# Altium schematic sheet led_clock-LEDR.SchDoc
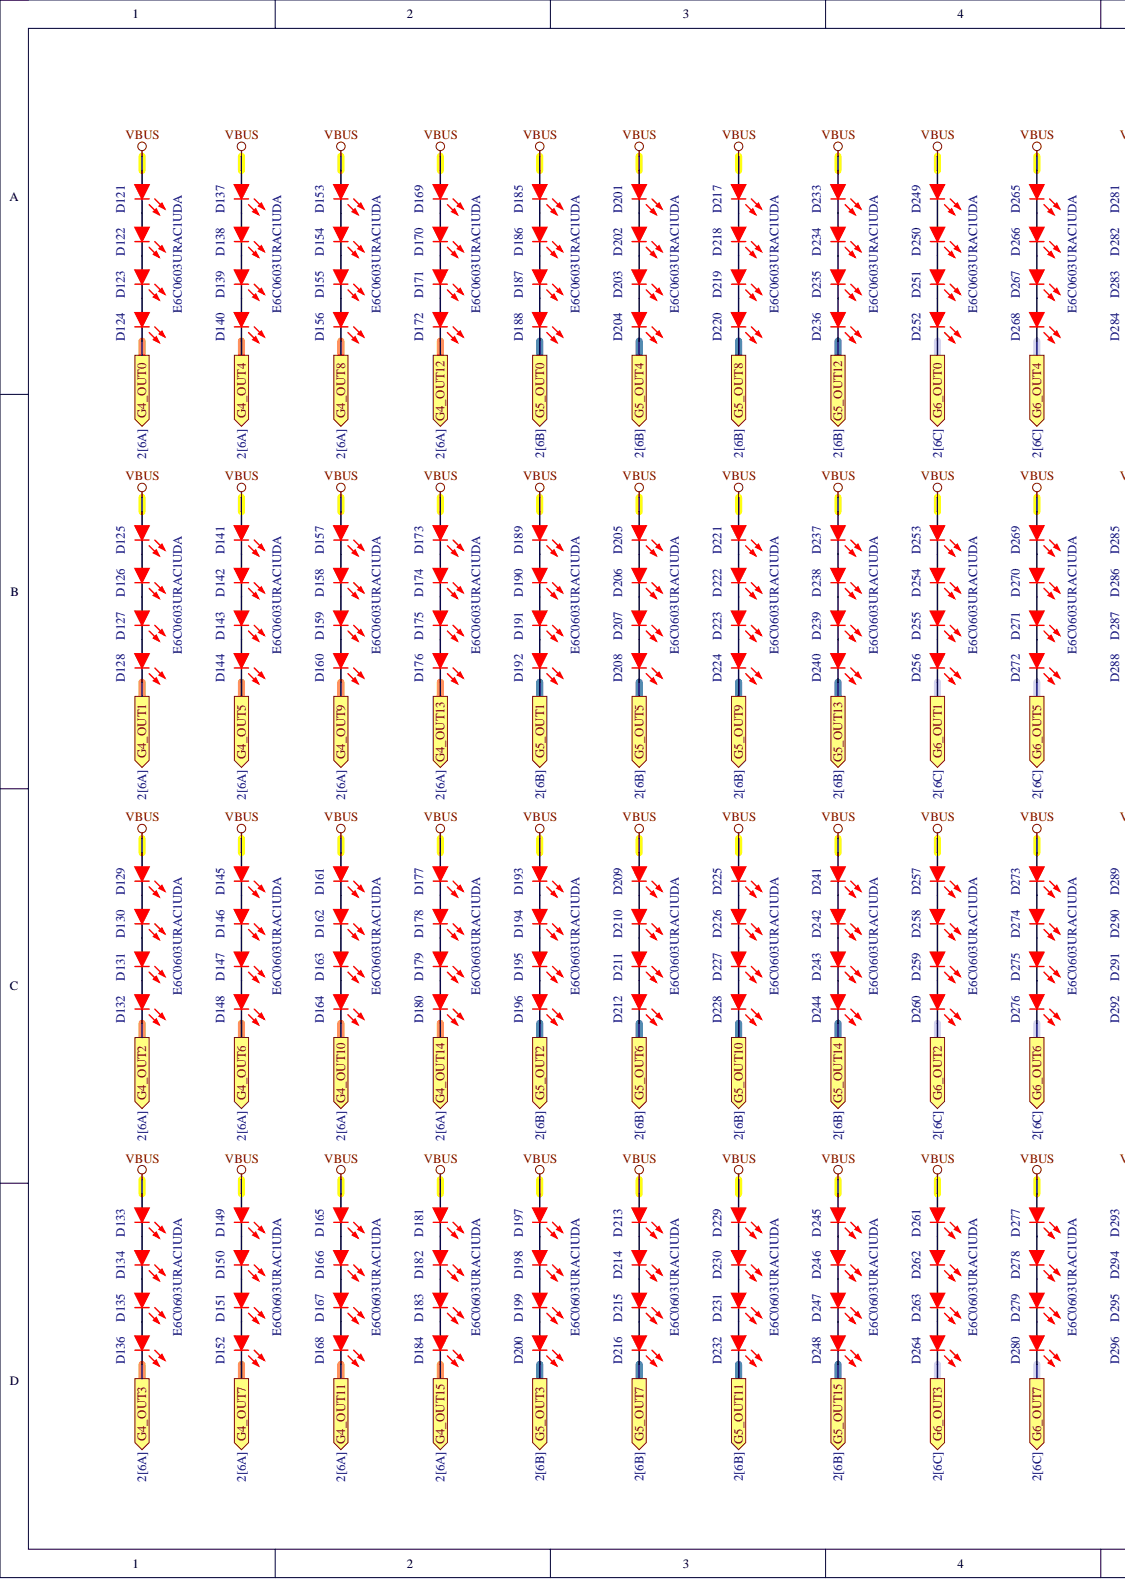
[diagram p0: led_clock-LEDR.SchDoc, left]
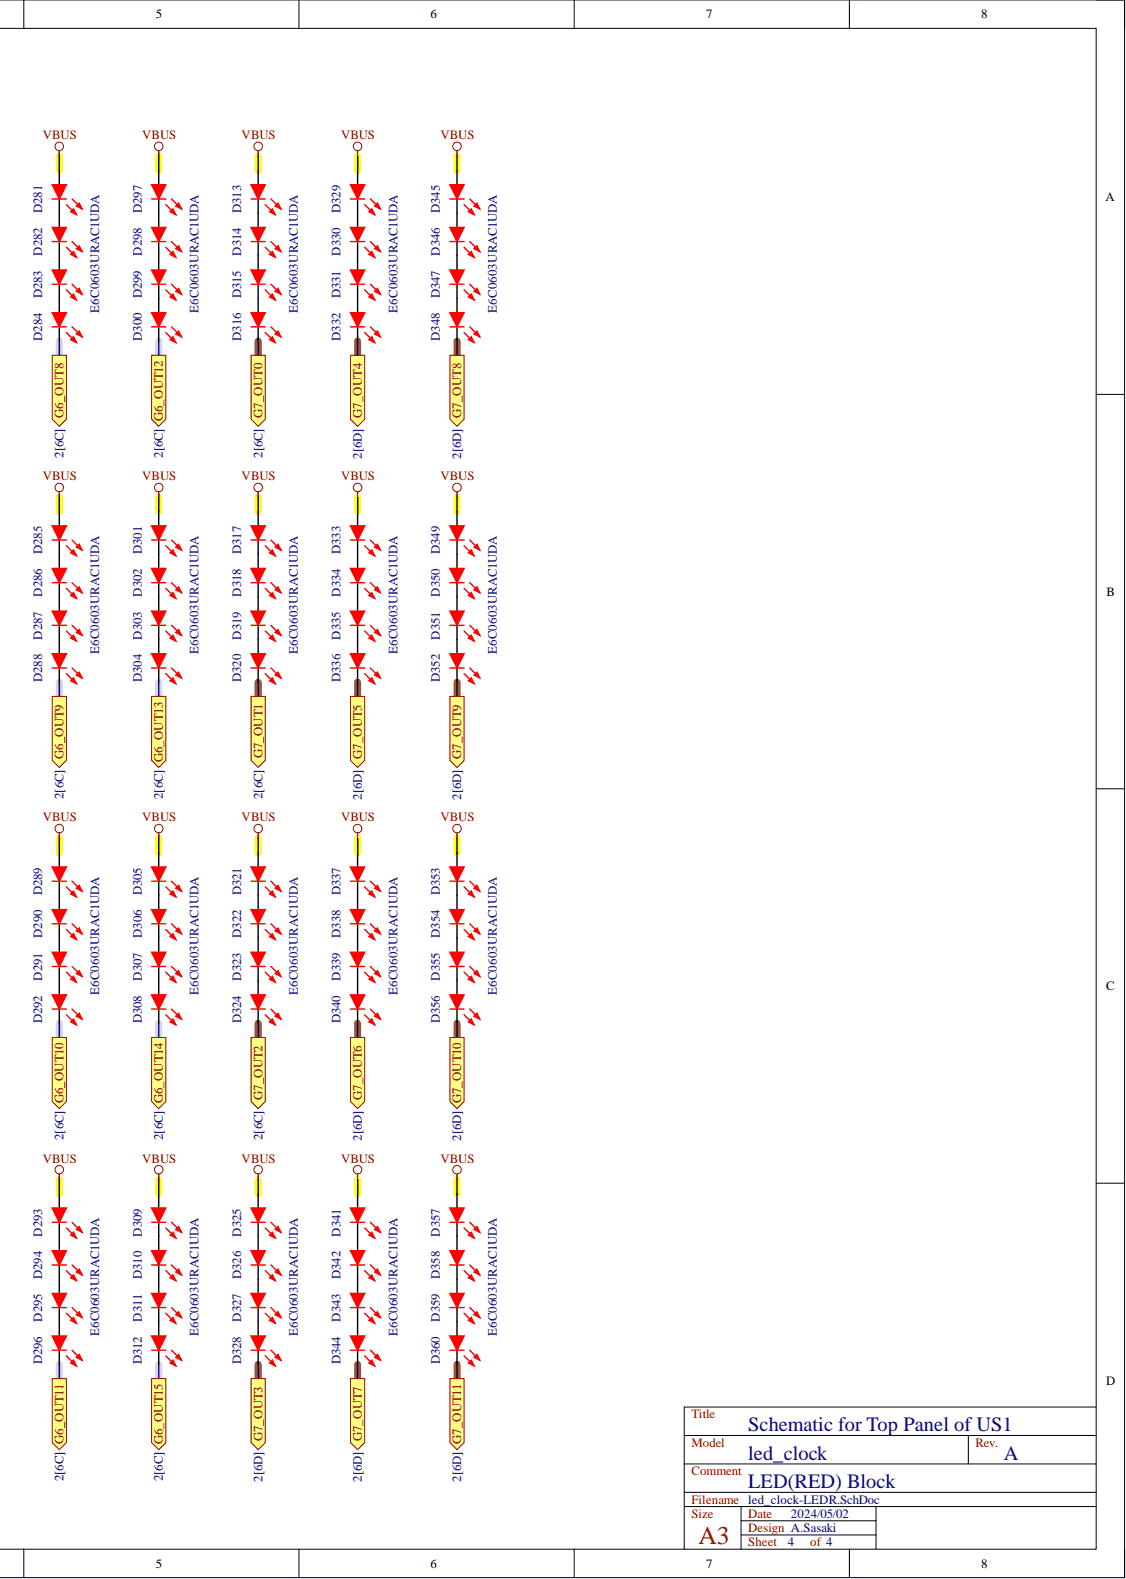
[diagram p1: led_clock-LEDR.SchDoc, right]

## Parts
D121  E6C0603URAC1UDA  [LED1608X04N_ROTATED]
D122  E6C0603URAC1UDA  [LED1608X04N_ROTATED]
D123  E6C0603URAC1UDA  [LED1608X04N_ROTATED]
D124  E6C0603URAC1UDA  [LED1608X04N_ROTATED]
D125  E6C0603URAC1UDA  [LED1608X04N_ROTATED]
D126  E6C0603URAC1UDA  [LED1608X04N_ROTATED]
D127  E6C0603URAC1UDA  [LED1608X04N_ROTATED]
D128  E6C0603URAC1UDA  [LED1608X04N_ROTATED]
D129  E6C0603URAC1UDA  [LED1608X04N_ROTATED]
D130  E6C0603URAC1UDA  [LED1608X04N_ROTATED]
D131  E6C0603URAC1UDA  [LED1608X04N_ROTATED]
D132  E6C0603URAC1UDA  [LED1608X04N_ROTATED]
D133  E6C0603URAC1UDA  [LED1608X04N_ROTATED]
D134  E6C0603URAC1UDA  [LED1608X04N_ROTATED]
D135  E6C0603URAC1UDA  [LED1608X04N_ROTATED]
D136  E6C0603URAC1UDA  [LED1608X04N_ROTATED]
D137  E6C0603URAC1UDA  [LED1608X04N_ROTATED]
D138  E6C0603URAC1UDA  [LED1608X04N_ROTATED]
D139  E6C0603URAC1UDA  [LED1608X04N_ROTATED]
D140  E6C0603URAC1UDA  [LED1608X04N_ROTATED]
D141  E6C0603URAC1UDA  [LED1608X04N_ROTATED]
D142  E6C0603URAC1UDA  [LED1608X04N_ROTATED]
D143  E6C0603URAC1UDA  [LED1608X04N_ROTATED]
D144  E6C0603URAC1UDA  [LED1608X04N_ROTATED]
D145  E6C0603URAC1UDA  [LED1608X04N_ROTATED]
D146  E6C0603URAC1UDA  [LED1608X04N_ROTATED]
D147  E6C0603URAC1UDA  [LED1608X04N_ROTATED]
D148  E6C0603URAC1UDA  [LED1608X04N_ROTATED]
D149  E6C0603URAC1UDA  [LED1608X04N_ROTATED]
D150  E6C0603URAC1UDA  [LED1608X04N_ROTATED]
D151  E6C0603URAC1UDA  [LED1608X04N_ROTATED]
D152  E6C0603URAC1UDA  [LED1608X04N_ROTATED]
D153  E6C0603URAC1UDA  [LED1608X04N_ROTATED]
D154  E6C0603URAC1UDA  [LED1608X04N_ROTATED]
D155  E6C0603URAC1UDA  [LED1608X04N_ROTATED]
D156  E6C0603URAC1UDA  [LED1608X04N_ROTATED]
D157  E6C0603URAC1UDA  [LED1608X04N_ROTATED]
D158  E6C0603URAC1UDA  [LED1608X04N_ROTATED]
D159  E6C0603URAC1UDA  [LED1608X04N_ROTATED]
D160  E6C0603URAC1UDA  [LED1608X04N_ROTATED]
D161  E6C0603URAC1UDA  [LED1608X04N_ROTATED]
D162  E6C0603URAC1UDA  [LED1608X04N_ROTATED]
D163  E6C0603URAC1UDA  [LED1608X04N_ROTATED]
D164  E6C0603URAC1UDA  [LED1608X04N_ROTATED]
D165  E6C0603URAC1UDA  [LED1608X04N_ROTATED]
D166  E6C0603URAC1UDA  [LED1608X04N_ROTATED]
D167  E6C0603URAC1UDA  [LED1608X04N_ROTATED]
D168  E6C0603URAC1UDA  [LED1608X04N_ROTATED]
D169  E6C0603URAC1UDA  [LED1608X04N_ROTATED]
D170  E6C0603URAC1UDA  [LED1608X04N_ROTATED]
D171  E6C0603URAC1UDA  [LED1608X04N_ROTATED]
D172  E6C0603URAC1UDA  [LED1608X04N_ROTATED]
D173  E6C0603URAC1UDA  [LED1608X04N_ROTATED]
D174  E6C0603URAC1UDA  [LED1608X04N_ROTATED]
D175  E6C0603URAC1UDA  [LED1608X04N_ROTATED]
D176  E6C0603URAC1UDA  [LED1608X04N_ROTATED]
D177  E6C0603URAC1UDA  [LED1608X04N_ROTATED]
D178  E6C0603URAC1UDA  [LED1608X04N_ROTATED]
D179  E6C0603URAC1UDA  [LED1608X04N_ROTATED]
D180  E6C0603URAC1UDA  [LED1608X04N_ROTATED]
D181  E6C0603URAC1UDA  [LED1608X04N_ROTATED]
D182  E6C0603URAC1UDA  [LED1608X04N_ROTATED]
D183  E6C0603URAC1UDA  [LED1608X04N_ROTATED]
D184  E6C0603URAC1UDA  [LED1608X04N_ROTATED]
D185  E6C0603URAC1UDA  [LED1608X04N_ROTATED]
D186  E6C0603URAC1UDA  [LED1608X04N_ROTATED]
D187  E6C0603URAC1UDA  [LED1608X04N_ROTATED]
D188  E6C0603URAC1UDA  [LED1608X04N_ROTATED]
D189  E6C0603URAC1UDA  [LED1608X04N_ROTATED]
D190  E6C0603URAC1UDA  [LED1608X04N_ROTATED]
D191  E6C0603URAC1UDA  [LED1608X04N_ROTATED]
D192  E6C0603URAC1UDA  [LED1608X04N_ROTATED]
D193  E6C0603URAC1UDA  [LED1608X04N_ROTATED]
D194  E6C0603URAC1UDA  [LED1608X04N_ROTATED]
D195  E6C0603URAC1UDA  [LED1608X04N_ROTATED]
D196  E6C0603URAC1UDA  [LED1608X04N_ROTATED]
D197  E6C0603URAC1UDA  [LED1608X04N_ROTATED]
D198  E6C0603URAC1UDA  [LED1608X04N_ROTATED]
D199  E6C0603URAC1UDA  [LED1608X04N_ROTATED]
D200  E6C0603URAC1UDA  [LED1608X04N_ROTATED]
D201  E6C0603URAC1UDA  [LED1608X04N_ROTATED]
D202  E6C0603URAC1UDA  [LED1608X04N_ROTATED]
D203  E6C0603URAC1UDA  [LED1608X04N_ROTATED]
D204  E6C0603URAC1UDA  [LED1608X04N_ROTATED]
D205  E6C0603URAC1UDA  [LED1608X04N_ROTATED]
D206  E6C0603URAC1UDA  [LED1608X04N_ROTATED]
D207  E6C0603URAC1UDA  [LED1608X04N_ROTATED]
D208  E6C0603URAC1UDA  [LED1608X04N_ROTATED]
D209  E6C0603URAC1UDA  [LED1608X04N_ROTATED]
D210  E6C0603URAC1UDA  [LED1608X04N_ROTATED]
D211  E6C0603URAC1UDA  [LED1608X04N_ROTATED]
D212  E6C0603URAC1UDA  [LED1608X04N_ROTATED]
D213  E6C0603URAC1UDA  [LED1608X04N_ROTATED]
D214  E6C0603URAC1UDA  [LED1608X04N_ROTATED]
D215  E6C0603URAC1UDA  [LED1608X04N_ROTATED]
D216  E6C0603URAC1UDA  [LED1608X04N_ROTATED]
D217  E6C0603URAC1UDA  [LED1608X04N_ROTATED]
D218  E6C0603URAC1UDA  [LED1608X04N_ROTATED]
D219  E6C0603URAC1UDA  [LED1608X04N_ROTATED]
D220  E6C0603URAC1UDA  [LED1608X04N_ROTATED]
D221  E6C0603URAC1UDA  [LED1608X04N_ROTATED]
D222  E6C0603URAC1UDA  [LED1608X04N_ROTATED]
D223  E6C0603URAC1UDA  [LED1608X04N_ROTATED]
D224  E6C0603URAC1UDA  [LED1608X04N_ROTATED]
D225  E6C0603URAC1UDA  [LED1608X04N_ROTATED]
D226  E6C0603URAC1UDA  [LED1608X04N_ROTATED]
D227  E6C0603URAC1UDA  [LED1608X04N_ROTATED]
D228  E6C0603URAC1UDA  [LED1608X04N_ROTATED]
D229  E6C0603URAC1UDA  [LED1608X04N_ROTATED]
D230  E6C0603URAC1UDA  [LED1608X04N_ROTATED]
D231  E6C0603URAC1UDA  [LED1608X04N_ROTATED]
D232  E6C0603URAC1UDA  [LED1608X04N_ROTATED]
D233  E6C0603URAC1UDA  [LED1608X04N_ROTATED]
D234  E6C0603URAC1UDA  [LED1608X04N_ROTATED]
D235  E6C0603URAC1UDA  [LED1608X04N_ROTATED]
D236  E6C0603URAC1UDA  [LED1608X04N_ROTATED]
D237  E6C0603URAC1UDA  [LED1608X04N_ROTATED]
D238  E6C0603URAC1UDA  [LED1608X04N_ROTATED]
D239  E6C0603URAC1UDA  [LED1608X04N_ROTATED]
D240  E6C0603URAC1UDA  [LED1608X04N_ROTATED]
D241  E6C0603URAC1UDA  [LED1608X04N_ROTATED]
D242  E6C0603URAC1UDA  [LED1608X04N_ROTATED]
D243  E6C0603URAC1UDA  [LED1608X04N_ROTATED]
D244  E6C0603URAC1UDA  [LED1608X04N_ROTATED]
D245  E6C0603URAC1UDA  [LED1608X04N_ROTATED]
D246  E6C0603URAC1UDA  [LED1608X04N_ROTATED]
D247  E6C0603URAC1UDA  [LED1608X04N_ROTATED]
D248  E6C0603URAC1UDA  [LED1608X04N_ROTATED]
D249  E6C0603URAC1UDA  [LED1608X04N_ROTATED]
D250  E6C0603URAC1UDA  [LED1608X04N_ROTATED]
D251  E6C0603URAC1UDA  [LED1608X04N_ROTATED]
D252  E6C0603URAC1UDA  [LED1608X04N_ROTATED]
D253  E6C0603URAC1UDA  [LED1608X04N_ROTATED]
D254  E6C0603URAC1UDA  [LED1608X04N_ROTATED]
D255  E6C0603URAC1UDA  [LED1608X04N_ROTATED]
D256  E6C0603URAC1UDA  [LED1608X04N_ROTATED]
D257  E6C0603URAC1UDA  [LED1608X04N_ROTATED]
D258  E6C0603URAC1UDA  [LED1608X04N_ROTATED]
D259  E6C0603URAC1UDA  [LED1608X04N_ROTATED]
D260  E6C0603URAC1UDA  [LED1608X04N_ROTATED]
D261  E6C0603URAC1UDA  [LED1608X04N_ROTATED]
D262  E6C0603URAC1UDA  [LED1608X04N_ROTATED]
D263  E6C0603URAC1UDA  [LED1608X04N_ROTATED]
D264  E6C0603URAC1UDA  [LED1608X04N_ROTATED]
D265  E6C0603URAC1UDA  [LED1608X04N_ROTATED]
D266  E6C0603URAC1UDA  [LED1608X04N_ROTATED]
D267  E6C0603URAC1UDA  [LED1608X04N_ROTATED]
D268  E6C0603URAC1UDA  [LED1608X04N_ROTATED]
D269  E6C0603URAC1UDA  [LED1608X04N_ROTATED]
D270  E6C0603URAC1UDA  [LED1608X04N_ROTATED]
D271  E6C0603URAC1UDA  [LED1608X04N_ROTATED]
D272  E6C0603URAC1UDA  [LED1608X04N_ROTATED]
D273  E6C0603URAC1UDA  [LED1608X04N_ROTATED]
D274  E6C0603URAC1UDA  [LED1608X04N_ROTATED]
D275  E6C0603URAC1UDA  [LED1608X04N_ROTATED]
D276  E6C0603URAC1UDA  [LED1608X04N_ROTATED]
D277  E6C0603URAC1UDA  [LED1608X04N_ROTATED]
D278  E6C0603URAC1UDA  [LED1608X04N_ROTATED]
D279  E6C0603URAC1UDA  [LED1608X04N_ROTATED]
D280  E6C0603URAC1UDA  [LED1608X04N_ROTATED]
D281  E6C0603URAC1UDA  [LED1608X04N_ROTATED]
D282  E6C0603URAC1UDA  [LED1608X04N_ROTATED]
D283  E6C0603URAC1UDA  [LED1608X04N_ROTATED]
D284  E6C0603URAC1UDA  [LED1608X04N_ROTATED]
D285  E6C0603URAC1UDA  [LED1608X04N_ROTATED]
D286  E6C0603URAC1UDA  [LED1608X04N_ROTATED]
D287  E6C0603URAC1UDA  [LED1608X04N_ROTATED]
D288  E6C0603URAC1UDA  [LED1608X04N_ROTATED]
D289  E6C0603URAC1UDA  [LED1608X04N_ROTATED]
D290  E6C0603URAC1UDA  [LED1608X04N_ROTATED]
D291  E6C0603URAC1UDA  [LED1608X04N_ROTATED]
D292  E6C0603URAC1UDA  [LED1608X04N_ROTATED]
D293  E6C0603URAC1UDA  [LED1608X04N_ROTATED]
D294  E6C0603URAC1UDA  [LED1608X04N_ROTATED]
D295  E6C0603URAC1UDA  [LED1608X04N_ROTATED]
D296  E6C0603URAC1UDA  [LED1608X04N_ROTATED]
D297  E6C0603URAC1UDA  [LED1608X04N_ROTATED]
D298  E6C0603URAC1UDA  [LED1608X04N_ROTATED]
D299  E6C0603URAC1UDA  [LED1608X04N_ROTATED]
D300  E6C0603URAC1UDA  [LED1608X04N_ROTATED]
D301  E6C0603URAC1UDA  [LED1608X04N_ROTATED]
D302  E6C0603URAC1UDA  [LED1608X04N_ROTATED]
D303  E6C0603URAC1UDA  [LED1608X04N_ROTATED]
D304  E6C0603URAC1UDA  [LED1608X04N_ROTATED]
D305  E6C0603URAC1UDA  [LED1608X04N_ROTATED]
D306  E6C0603URAC1UDA  [LED1608X04N_ROTATED]
D307  E6C0603URAC1UDA  [LED1608X04N_ROTATED]
D308  E6C0603URAC1UDA  [LED1608X04N_ROTATED]
D309  E6C0603URAC1UDA  [LED1608X04N_ROTATED]
D310  E6C0603URAC1UDA  [LED1608X04N_ROTATED]
D311  E6C0603URAC1UDA  [LED1608X04N_ROTATED]
D312  E6C0603URAC1UDA  [LED1608X04N_ROTATED]
D313  E6C0603URAC1UDA  [LED1608X04N_ROTATED]
D314  E6C0603URAC1UDA  [LED1608X04N_ROTATED]
D315  E6C0603URAC1UDA  [LED1608X04N_ROTATED]
D316  E6C0603URAC1UDA  [LED1608X04N_ROTATED]
D317  E6C0603URAC1UDA  [LED1608X04N_ROTATED]
D318  E6C0603URAC1UDA  [LED1608X04N_ROTATED]
D319  E6C0603URAC1UDA  [LED1608X04N_ROTATED]
D320  E6C0603URAC1UDA  [LED1608X04N_ROTATED]
D321  E6C0603URAC1UDA  [LED1608X04N_ROTATED]
D322  E6C0603URAC1UDA  [LED1608X04N_ROTATED]
D323  E6C0603URAC1UDA  [LED1608X04N_ROTATED]
D324  E6C0603URAC1UDA  [LED1608X04N_ROTATED]
D325  E6C0603URAC1UDA  [LED1608X04N_ROTATED]
D326  E6C0603URAC1UDA  [LED1608X04N_ROTATED]
D327  E6C0603URAC1UDA  [LED1608X04N_ROTATED]
D328  E6C0603URAC1UDA  [LED1608X04N_ROTATED]
D329  E6C0603URAC1UDA  [LED1608X04N_ROTATED]
D330  E6C0603URAC1UDA  [LED1608X04N_ROTATED]
D331  E6C0603URAC1UDA  [LED1608X04N_ROTATED]
D332  E6C0603URAC1UDA  [LED1608X04N_ROTATED]
D333  E6C0603URAC1UDA  [LED1608X04N_ROTATED]
D334  E6C0603URAC1UDA  [LED1608X04N_ROTATED]
D335  E6C0603URAC1UDA  [LED1608X04N_ROTATED]
D336  E6C0603URAC1UDA  [LED1608X04N_ROTATED]
D337  E6C0603URAC1UDA  [LED1608X04N_ROTATED]
D338  E6C0603URAC1UDA  [LED1608X04N_ROTATED]
D339  E6C0603URAC1UDA  [LED1608X04N_ROTATED]
D340  E6C0603URAC1UDA  [LED1608X04N_ROTATED]
D341  E6C0603URAC1UDA  [LED1608X04N_ROTATED]
D342  E6C0603URAC1UDA  [LED1608X04N_ROTATED]
D343  E6C0603URAC1UDA  [LED1608X04N_ROTATED]
D344  E6C0603URAC1UDA  [LED1608X04N_ROTATED]
D345  E6C0603URAC1UDA  [LED1608X04N_ROTATED]
D346  E6C0603URAC1UDA  [LED1608X04N_ROTATED]
D347  E6C0603URAC1UDA  [LED1608X04N_ROTATED]
D348  E6C0603URAC1UDA  [LED1608X04N_ROTATED]
D349  E6C0603URAC1UDA  [LED1608X04N_ROTATED]
D350  E6C0603URAC1UDA  [LED1608X04N_ROTATED]
D351  E6C0603URAC1UDA  [LED1608X04N_ROTATED]
D352  E6C0603URAC1UDA  [LED1608X04N_ROTATED]
D353  E6C0603URAC1UDA  [LED1608X04N_ROTATED]
D354  E6C0603URAC1UDA  [LED1608X04N_ROTATED]
D355  E6C0603URAC1UDA  [LED1608X04N_ROTATED]
D356  E6C0603URAC1UDA  [LED1608X04N_ROTATED]
D357  E6C0603URAC1UDA  [LED1608X04N_ROTATED]
D358  E6C0603URAC1UDA  [LED1608X04N_ROTATED]
D359  E6C0603URAC1UDA  [LED1608X04N_ROTATED]
D360  E6C0603URAC1UDA  [LED1608X04N_ROTATED]

## Ports
G4_OUT0, G4_OUT1, G4_OUT2, G4_OUT3, G4_OUT4, G4_OUT5, G4_OUT6, G4_OUT7, G4_OUT8, G4_OUT9, G4_OUT10, G4_OUT11, G4_OUT12, G4_OUT13, G4_OUT14, G4_OUT15, G5_OUT0, G5_OUT1, G5_OUT2, G5_OUT3, G5_OUT4, G5_OUT5, G5_OUT6, G5_OUT7, G5_OUT8, G5_OUT9, G5_OUT10, G5_OUT11, G5_OUT12, G5_OUT13, G5_OUT14, G5_OUT15, G6_OUT0, G6_OUT1, G6_OUT2, G6_OUT3, G6_OUT4, G6_OUT5, G6_OUT6, G6_OUT7, G6_OUT8, G6_OUT9, G6_OUT10, G6_OUT11, G6_OUT12, G6_OUT13, G6_OUT14, G6_OUT15, G7_OUT0, G7_OUT1, G7_OUT2, G7_OUT3, G7_OUT4, G7_OUT5, G7_OUT6, G7_OUT7, G7_OUT8, G7_OUT9, G7_OUT10, G7_OUT11

## Nets
VBUS: D121.1 D125.1 D129.1 D133.1 D137.1 D141.1 D145.1 D149.1 D153.1 D157.1 D161.1 D165.1 D169.1 D173.1 D177.1 D181.1 D185.1 D189.1 D193.1 D197.1 D201.1 D205.1 D209.1 D213.1 D217.1 D221.1 D225.1 D229.1 D233.1 D237.1 D241.1 D245.1 D249.1 D253.1 D257.1 D261.1 D265.1 D269.1 D273.1 D277.1 D281.1 D285.1 D289.1 D293.1 D297.1 D301.1 D305.1 D309.1 D313.1 D317.1 D321.1 D325.1 D329.1 D333.1 D337.1 D341.1 D345.1 D349.1 D353.1 D357.1
G4_OUT0: D124.2 ; port G4_OUT0
G4_OUT1: D128.2 ; port G4_OUT1
G4_OUT2: D132.2 ; port G4_OUT2
G4_OUT3: D136.2 ; port G4_OUT3
G4_OUT4: D140.2 ; port G4_OUT4
G4_OUT5: D144.2 ; port G4_OUT5
G4_OUT6: D148.2 ; port G4_OUT6
G4_OUT7: D152.2 ; port G4_OUT7
G4_OUT8: D156.2 ; port G4_OUT8
G4_OUT9: D160.2 ; port G4_OUT9
G4_OUT10: D164.2 ; port G4_OUT10
G4_OUT11: D168.2 ; port G4_OUT11
G4_OUT12: D172.2 ; port G4_OUT12
G4_OUT13: D176.2 ; port G4_OUT13
G4_OUT14: D180.2 ; port G4_OUT14
G4_OUT15: D184.2 ; port G4_OUT15
G5_OUT0: D188.2 ; port G5_OUT0
G5_OUT1: D192.2 ; port G5_OUT1
G5_OUT2: D196.2 ; port G5_OUT2
G5_OUT3: D200.2 ; port G5_OUT3
G5_OUT4: D204.2 ; port G5_OUT4
G5_OUT5: D208.2 ; port G5_OUT5
G5_OUT6: D212.2 ; port G5_OUT6
G5_OUT7: D216.2 ; port G5_OUT7
G5_OUT8: D220.2 ; port G5_OUT8
G5_OUT9: D224.2 ; port G5_OUT9
G5_OUT10: D228.2 ; port G5_OUT10
G5_OUT11: D232.2 ; port G5_OUT11
G5_OUT12: D236.2 ; port G5_OUT12
G5_OUT13: D240.2 ; port G5_OUT13
G5_OUT14: D244.2 ; port G5_OUT14
G5_OUT15: D248.2 ; port G5_OUT15
G6_OUT0: D252.2 ; port G6_OUT0
G6_OUT1: D256.2 ; port G6_OUT1
G6_OUT2: D260.2 ; port G6_OUT2
G6_OUT3: D264.2 ; port G6_OUT3
G6_OUT4: D268.2 ; port G6_OUT4
G6_OUT5: D272.2 ; port G6_OUT5
G6_OUT6: D276.2 ; port G6_OUT6
G6_OUT7: D280.2 ; port G6_OUT7
G6_OUT8: D284.2 ; port G6_OUT8
G6_OUT9: D288.2 ; port G6_OUT9
G6_OUT10: D292.2 ; port G6_OUT10
G6_OUT11: D296.2 ; port G6_OUT11
G6_OUT12: D300.2 ; port G6_OUT12
G6_OUT13: D304.2 ; port G6_OUT13
G6_OUT14: D308.2 ; port G6_OUT14
G6_OUT15: D312.2 ; port G6_OUT15
G7_OUT0: D316.2 ; port G7_OUT0
G7_OUT1: D320.2 ; port G7_OUT1
G7_OUT2: D324.2 ; port G7_OUT2
G7_OUT3: D328.2 ; port G7_OUT3
G7_OUT4: D332.2 ; port G7_OUT4
G7_OUT5: D336.2 ; port G7_OUT5
G7_OUT6: D340.2 ; port G7_OUT6
G7_OUT7: D344.2 ; port G7_OUT7
G7_OUT8: D348.2 ; port G7_OUT8
G7_OUT9: D352.2 ; port G7_OUT9
G7_OUT10: D356.2 ; port G7_OUT10
G7_OUT11: D360.2 ; port G7_OUT11
NetD121_2: D121.2 D122.1
NetD122_2: D122.2 D123.1
NetD123_2: D123.2 D124.1
NetD125_2: D125.2 D126.1
NetD126_2: D126.2 D127.1
NetD127_2: D127.2 D128.1
NetD129_2: D129.2 D130.1
NetD130_2: D130.2 D131.1
NetD131_2: D131.2 D132.1
NetD133_2: D133.2 D134.1
NetD134_2: D134.2 D135.1
NetD135_2: D135.2 D136.1
NetD137_2: D137.2 D138.1
NetD138_2: D138.2 D139.1
NetD139_2: D139.2 D140.1
NetD141_2: D141.2 D142.1
NetD142_2: D142.2 D143.1
NetD143_2: D143.2 D144.1
NetD145_2: D145.2 D146.1
NetD146_2: D146.2 D147.1
NetD147_2: D147.2 D148.1
NetD149_2: D149.2 D150.1
NetD150_2: D150.2 D151.1
NetD151_2: D151.2 D152.1
NetD153_2: D153.2 D154.1
NetD154_2: D154.2 D155.1
NetD155_2: D155.2 D156.1
NetD157_2: D157.2 D158.1
NetD158_2: D158.2 D159.1
NetD159_2: D159.2 D160.1
NetD161_2: D161.2 D162.1
NetD162_2: D162.2 D163.1
NetD163_2: D163.2 D164.1
NetD165_2: D165.2 D166.1
NetD166_2: D166.2 D167.1
NetD167_2: D167.2 D168.1
NetD169_2: D169.2 D170.1
NetD170_2: D170.2 D171.1
NetD171_2: D171.2 D172.1
NetD173_2: D173.2 D174.1
NetD174_2: D174.2 D175.1
NetD175_2: D175.2 D176.1
NetD177_2: D177.2 D178.1
NetD178_2: D178.2 D179.1
NetD179_2: D179.2 D180.1
NetD181_2: D181.2 D182.1
NetD182_2: D182.2 D183.1
NetD183_2: D183.2 D184.1
NetD185_2: D185.2 D186.1
NetD186_2: D186.2 D187.1
NetD187_2: D187.2 D188.1
NetD189_2: D189.2 D190.1
NetD190_2: D190.2 D191.1
NetD191_2: D191.2 D192.1
NetD193_2: D193.2 D194.1
NetD194_2: D194.2 D195.1
NetD195_2: D195.2 D196.1
NetD197_2: D197.2 D198.1
NetD198_2: D198.2 D199.1
NetD199_2: D199.2 D200.1
NetD201_2: D201.2 D202.1
NetD202_2: D202.2 D203.1
NetD203_2: D203.2 D204.1
NetD205_2: D205.2 D206.1
NetD206_2: D206.2 D207.1
NetD207_2: D207.2 D208.1
NetD209_2: D209.2 D210.1
NetD210_2: D210.2 D211.1
NetD211_2: D211.2 D212.1
NetD213_2: D213.2 D214.1
NetD214_2: D214.2 D215.1
NetD215_2: D215.2 D216.1
NetD217_2: D217.2 D218.1
NetD218_2: D218.2 D219.1
NetD219_2: D219.2 D220.1
NetD221_2: D221.2 D222.1
NetD222_2: D222.2 D223.1
NetD223_2: D223.2 D224.1
NetD225_2: D225.2 D226.1
NetD226_2: D226.2 D227.1
NetD227_2: D227.2 D228.1
NetD229_2: D229.2 D230.1
NetD230_2: D230.2 D231.1
NetD231_2: D231.2 D232.1
NetD233_2: D233.2 D234.1
NetD234_2: D234.2 D235.1
NetD235_2: D235.2 D236.1
NetD237_2: D237.2 D238.1
NetD238_2: D238.2 D239.1
NetD239_2: D239.2 D240.1
NetD241_2: D241.2 D242.1
NetD242_2: D242.2 D243.1
NetD243_2: D243.2 D244.1
NetD245_2: D245.2 D246.1
NetD246_2: D246.2 D247.1
NetD247_2: D247.2 D248.1
NetD249_2: D249.2 D250.1
NetD250_2: D250.2 D251.1
NetD251_2: D251.2 D252.1
NetD253_2: D253.2 D254.1
NetD254_2: D254.2 D255.1
NetD255_2: D255.2 D256.1
NetD257_2: D257.2 D258.1
NetD258_2: D258.2 D259.1
NetD259_2: D259.2 D260.1
NetD261_2: D261.2 D262.1
NetD262_2: D262.2 D263.1
NetD263_2: D263.2 D264.1
NetD265_2: D265.2 D266.1
NetD266_2: D266.2 D267.1
NetD267_2: D267.2 D268.1
NetD269_2: D269.2 D270.1
NetD270_2: D270.2 D271.1
NetD271_2: D271.2 D272.1
NetD273_2: D273.2 D274.1
NetD274_2: D274.2 D275.1
NetD275_2: D275.2 D276.1
NetD277_2: D277.2 D278.1
NetD278_2: D278.2 D279.1
NetD279_2: D279.2 D280.1
NetD281_2: D281.2 D282.1
NetD282_2: D282.2 D283.1
NetD283_2: D283.2 D284.1
NetD285_2: D285.2 D286.1
NetD286_2: D286.2 D287.1
NetD287_2: D287.2 D288.1
NetD289_2: D289.2 D290.1
NetD290_2: D290.2 D291.1
NetD291_2: D291.2 D292.1
NetD293_2: D293.2 D294.1
NetD294_2: D294.2 D295.1
NetD295_2: D295.2 D296.1
NetD297_2: D297.2 D298.1
NetD298_2: D298.2 D299.1
NetD299_2: D299.2 D300.1
NetD301_2: D301.2 D302.1
NetD302_2: D302.2 D303.1
NetD303_2: D303.2 D304.1
NetD305_2: D305.2 D306.1
NetD306_2: D306.2 D307.1
NetD307_2: D307.2 D308.1
NetD309_2: D309.2 D310.1
NetD310_2: D310.2 D311.1
NetD311_2: D311.2 D312.1
NetD313_2: D313.2 D314.1
NetD314_2: D314.2 D315.1
NetD315_2: D315.2 D316.1
NetD317_2: D317.2 D318.1
NetD318_2: D318.2 D319.1
NetD319_2: D319.2 D320.1
NetD321_2: D321.2 D322.1
NetD322_2: D322.2 D323.1
NetD323_2: D323.2 D324.1
NetD325_2: D325.2 D326.1
NetD326_2: D326.2 D327.1
NetD327_2: D327.2 D328.1
NetD329_2: D329.2 D330.1
NetD330_2: D330.2 D331.1
NetD331_2: D331.2 D332.1
NetD333_2: D333.2 D334.1
NetD334_2: D334.2 D335.1
NetD335_2: D335.2 D336.1
NetD337_2: D337.2 D338.1
NetD338_2: D338.2 D339.1
NetD339_2: D339.2 D340.1
NetD341_2: D341.2 D342.1
NetD342_2: D342.2 D343.1
NetD343_2: D343.2 D344.1
NetD345_2: D345.2 D346.1
NetD346_2: D346.2 D347.1
NetD347_2: D347.2 D348.1
NetD349_2: D349.2 D350.1
NetD350_2: D350.2 D351.1
NetD351_2: D351.2 D352.1
NetD353_2: D353.2 D354.1
NetD354_2: D354.2 D355.1
NetD355_2: D355.2 D356.1
NetD357_2: D357.2 D358.1
NetD358_2: D358.2 D359.1
NetD359_2: D359.2 D360.1

## Library data of the parts
D121-D360 = E6C0603URAC1UDA: 1.6 x 0.8 x 0.55mm SMD 620nm RED LED; Manufacturer: EKINGLUX; Component Kind: Standard; LCSC Part Number: C375444; Library Name: Ekinglux.IntLib; Manufacturer Part Number: E6C0603URAC1UDA; PackageDescription: LED; 1608; Published: 2022/02/06; Publisher: Atsushi Sasaki
